# Altium schematic sheet H-9220 Backplane Board - DUT Bd5-6 (REV07).SchDoc
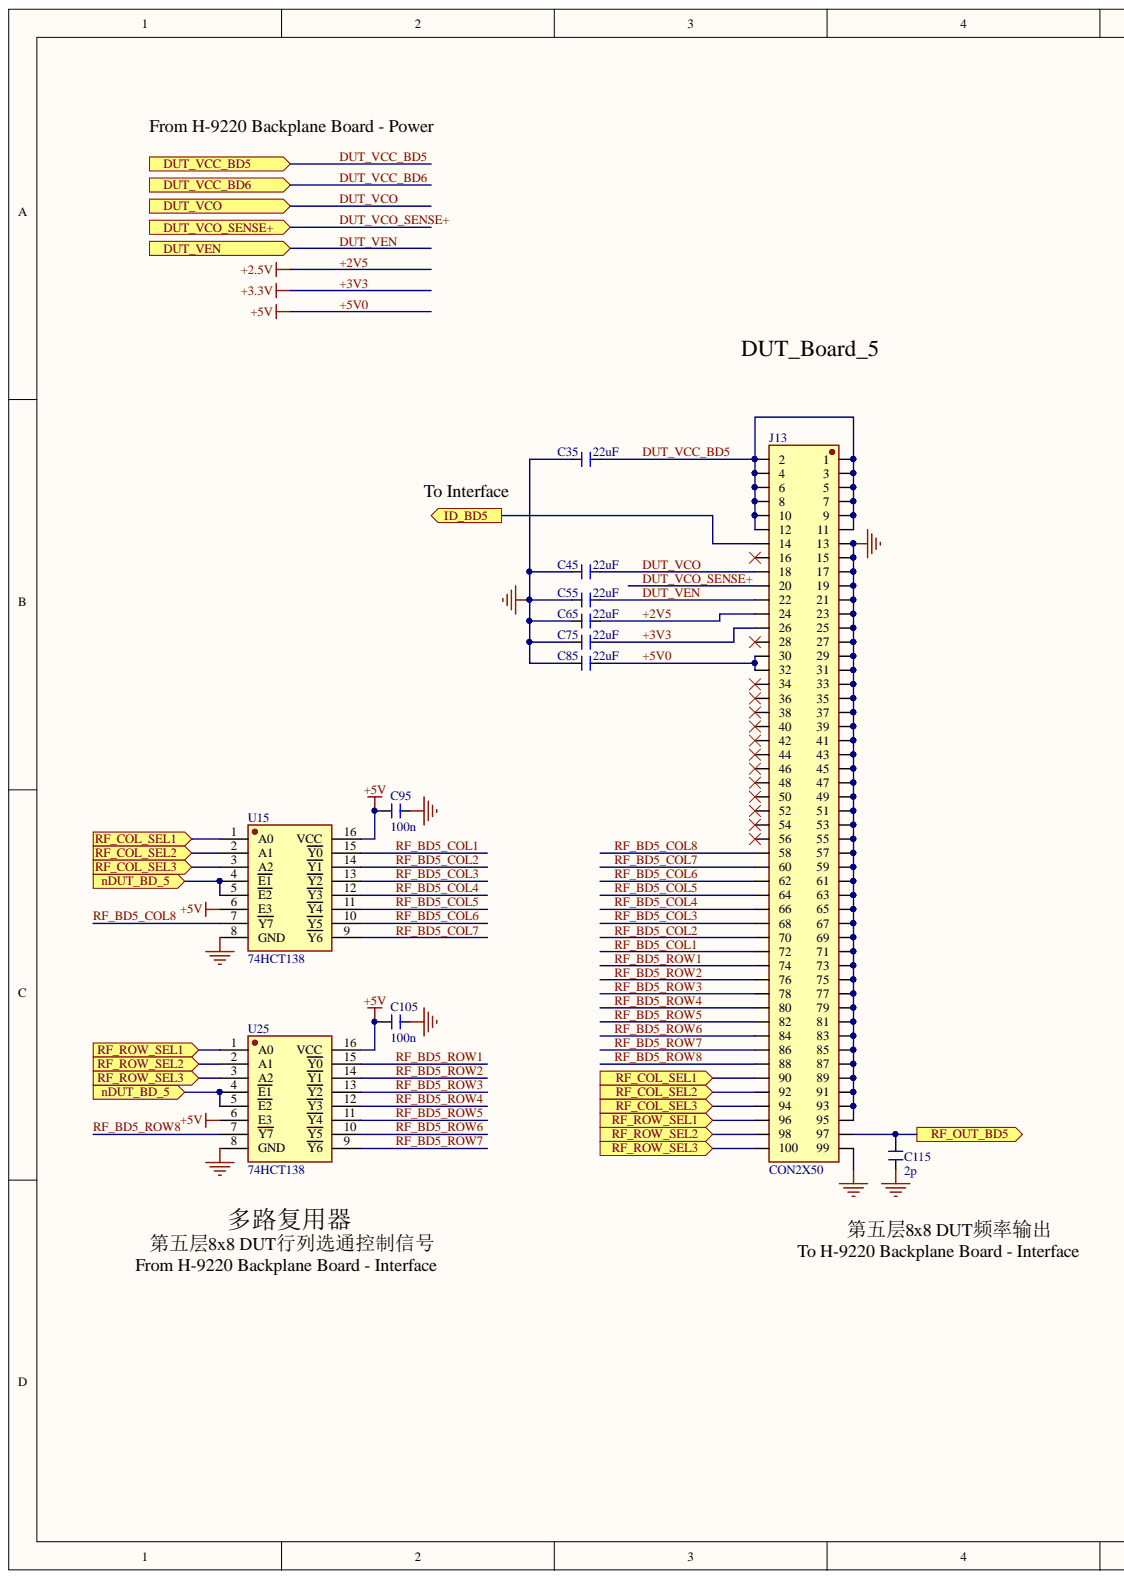
[diagram p0: H-9220 Backplane Board - DUT Bd5-6 (REV07).SchDoc, left]
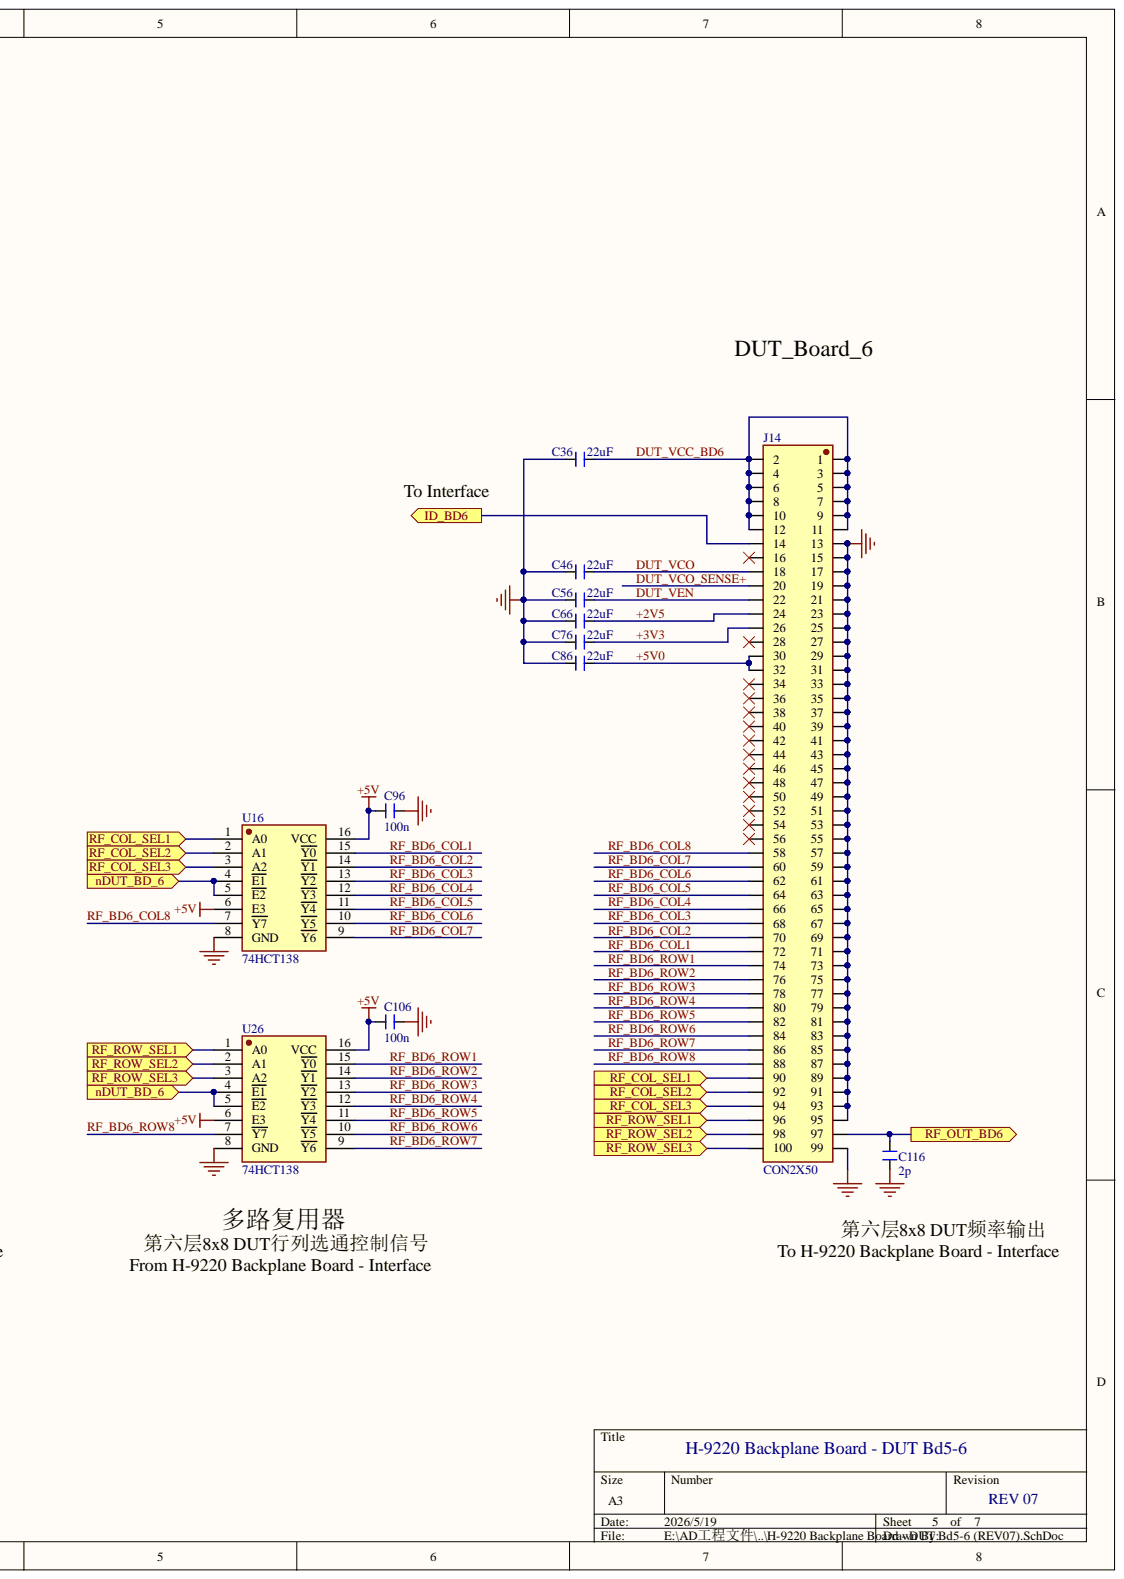
[diagram p1: H-9220 Backplane Board - DUT Bd5-6 (REV07).SchDoc, right]

## Parts
C35  22uF  [C-1206]
C36  22uF  [C-1206]
C45  22uF  [C-1206]
C46  22uF  [C-1206]
C55  22uF  [C-1206]
C56  22uF  [C-1206]
C65  22uF  [C-1206]
C66  22uF  [C-1206]
C75  22uF  [C-1206]
C76  22uF  [C-1206]
C85  22uF  [C-1206]
C86  22uF  [C-1206]
C95  100n  [C-0603]
C96  100n  [C-0603]
C105  100n  [C-0603]
C106  100n  [C-0603]
C115  2p  [C-0603]
C116  2p  [C-0603]
J13  CON2X50  [SFML-150-01-S-D-LC]
J14  CON2X50  [SFML-150-01-S-D-LC]
U15  74HCT138  [SOIC-16 (BODY=3.9MM)]
U16  74HCT138  [SOIC-16 (BODY=3.9MM)]
U25  74HCT138  [SOIC-16 (BODY=3.9MM)]
U26  74HCT138  [SOIC-16 (BODY=3.9MM)]

## Ports
DUT_VCC_BD5, DUT_VCC_BD6, DUT_VCO, DUT_VCO_SENSE+, DUT_VEN, ID_BD5, ID_BD6, nDUT_BD_5, nDUT_BD_6, RF_COL_SEL1, RF_COL_SEL2, RF_COL_SEL3, RF_OUT_BD5, RF_OUT_BD6, RF_ROW_SEL1, RF_ROW_SEL2, RF_ROW_SEL3

## Nets
GND: C35.2 C36.2 C45.2 C46.2 C55.2 C56.2 C65.2 C66.2 C75.2 C76.2 C85.2 C86.2 C95.2 C96.2 C105.2 C106.2 C115.2 C116.2 J13.13 J13.15 J13.17 J13.19 J13.21 J13.23 J13.25 J13.27 J13.29 J13.31 J13.33 J13.35 J13.37 J13.39 J13.41 J13.43 J13.45 J13.47 J13.49 J13.51 J13.53 J13.55 J13.57 J13.59 J13.61 J13.63 J13.65 J13.67 J13.69 J13.71 J13.73 J13.75 J13.77 J13.79 J13.81 J13.83 J13.85 J13.87 J13.89 J13.91 J13.93 J13.95 J13.99 J14.13 J14.15 J14.17 J14.19 J14.21 J14.23 J14.25 J14.27 J14.29 J14.31 J14.33 J14.35 J14.37 J14.39 J14.41 J14.43 J14.45 J14.47 J14.49 J14.51 J14.53 J14.55 J14.57 J14.59 J14.61 J14.63 J14.65 J14.67 J14.69 J14.71 J14.73 J14.75 J14.77 J14.79 J14.81 J14.83 J14.85 J14.87 J14.89 J14.91 J14.93 J14.95 J14.99 U15.8(GND) U16.8(GND) U25.8(GND) U26.8(GND)
+2V5: C65.1 C66.1 J13.24 J14.24
+3V3: C75.1 C76.1 J13.26 J14.26
+5V0: C85.1 C86.1 C95.1 C96.1 C105.1 C106.1 J13.30 J13.32 J14.30 J14.32 U15.6(E3) U15.16(VCC) U16.6(E3) U16.16(VCC) U25.6(E3) U25.16(VCC) U26.6(E3) U26.16(VCC)
DUT_VCC_BD5: C35.1 J13.1 J13.2 J13.3 J13.4 J13.5 J13.6 J13.7 J13.8 J13.9 J13.10 J13.11 J13.12 ; port DUT_VCC_BD5
DUT_VCC_BD6: C36.1 J14.1 J14.2 J14.3 J14.4 J14.5 J14.6 J14.7 J14.8 J14.9 J14.10 J14.11 J14.12 ; port DUT_VCC_BD6
DUT_VCO: C45.1 C46.1 J13.18 J14.18 ; port DUT_VCO
DUT_VCO_SENSE+: J13.20 J14.20 ; port DUT_VCO_SENSE+
DUT_VEN: C55.1 C56.1 J13.22 J14.22 ; port DUT_VEN
ID_BD5: J13.14 ; port ID_BD5
ID_BD6: J14.14 ; port ID_BD6
nDUT_BD_5: U15.4(E1) U15.5(E2) U25.4(E1) U25.5(E2) ; port nDUT_BD_5
nDUT_BD_6: U16.4(E1) U16.5(E2) U26.4(E1) U26.5(E2) ; port nDUT_BD_6
RF_BD5_COL1: J13.72 U15.15(Y0)
RF_BD5_COL2: J13.70 U15.14(Y1)
RF_BD5_COL3: J13.68 U15.13(Y2)
RF_BD5_COL4: J13.66 U15.12(Y3)
RF_BD5_COL5: J13.64 U15.11(Y4)
RF_BD5_COL6: J13.62 U15.10(Y5)
RF_BD5_COL7: J13.60 U15.9(Y6)
RF_BD5_COL8: J13.58 U15.7(Y7)
RF_BD5_ROW1: J13.74 U25.15(Y0)
RF_BD5_ROW2: J13.76 U25.14(Y1)
RF_BD5_ROW3: J13.78 U25.13(Y2)
RF_BD5_ROW4: J13.80 U25.12(Y3)
RF_BD5_ROW5: J13.82 U25.11(Y4)
RF_BD5_ROW6: J13.84 U25.10(Y5)
RF_BD5_ROW7: J13.86 U25.9(Y6)
RF_BD5_ROW8: J13.88 U25.7(Y7)
RF_BD6_COL1: J14.72 U16.15(Y0)
RF_BD6_COL2: J14.70 U16.14(Y1)
RF_BD6_COL3: J14.68 U16.13(Y2)
RF_BD6_COL4: J14.66 U16.12(Y3)
RF_BD6_COL5: J14.64 U16.11(Y4)
RF_BD6_COL6: J14.62 U16.10(Y5)
RF_BD6_COL7: J14.60 U16.9(Y6)
RF_BD6_COL8: J14.58 U16.7(Y7)
RF_BD6_ROW1: J14.74 U26.15(Y0)
RF_BD6_ROW2: J14.76 U26.14(Y1)
RF_BD6_ROW3: J14.78 U26.13(Y2)
RF_BD6_ROW4: J14.80 U26.12(Y3)
RF_BD6_ROW5: J14.82 U26.11(Y4)
RF_BD6_ROW6: J14.84 U26.10(Y5)
RF_BD6_ROW7: J14.86 U26.9(Y6)
RF_BD6_ROW8: J14.88 U26.7(Y7)
RF_COL_SEL1: J13.90 J14.90 U15.1(A0) U16.1(A0) ; port RF_COL_SEL1
RF_COL_SEL2: J13.92 J14.92 U15.2(A1) U16.2(A1) ; port RF_COL_SEL2
RF_COL_SEL3: J13.94 J14.94 U15.3(A2) U16.3(A2) ; port RF_COL_SEL3
RF_OUT_BD5: C115.1 J13.97 ; port RF_OUT_BD5
RF_OUT_BD6: C116.1 J14.97 ; port RF_OUT_BD6
RF_ROW_SEL1: J13.96 J14.96 U25.1(A0) U26.1(A0) ; port RF_ROW_SEL1
RF_ROW_SEL2: J13.98 J14.98 U25.2(A1) U26.2(A1) ; port RF_ROW_SEL2
RF_ROW_SEL3: J13.100 J14.100 U25.3(A2) U26.3(A2) ; port RF_ROW_SEL3

Not connected: J13 16 28 34 36 38 40 42 44 46 48 50 52 54 56; J14 16 28 34 36 38 40 42 44 46 48 50 52 54 56

## Library data of the parts
C35 C36 C45 C46 C55 C56 C65 C66 C75 C76 C85 C86 C95 C96 C105 C106 C115 C116 = CAP: Component Kind: Standard; Library Name: HW SCH Lib.SCHLIB
J13 J14 = CON-2x50P: Component Kind: Standard; Library Name: HW SCH Lib.SCHLIB
U15 U16 U25 U26 = 74HCT138: Component Kind: Standard; Library Name: HW SCH Lib.SCHLIB
